# Altium schematic sheet BGMCtrlInterface.SchDoc(BGMCtrlInterface)
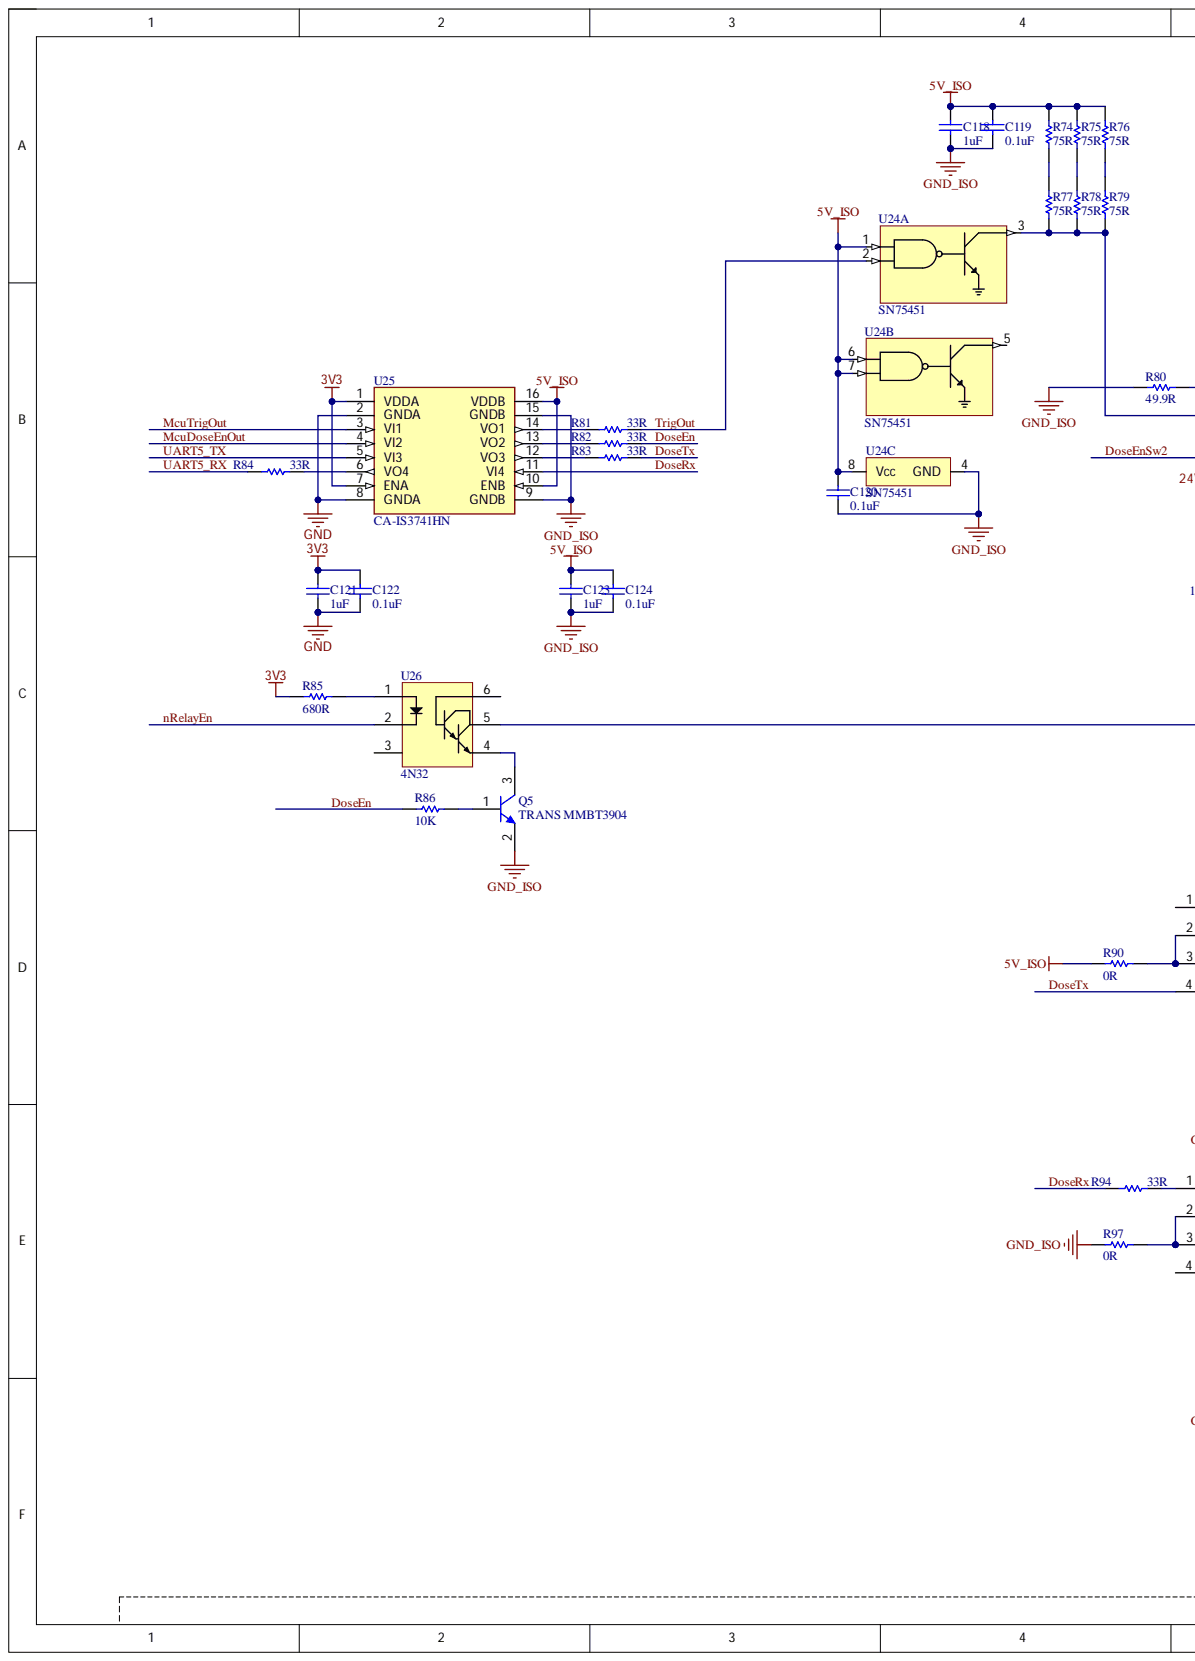
[diagram p0: BGMCtrlInterface.SchDoc, left]
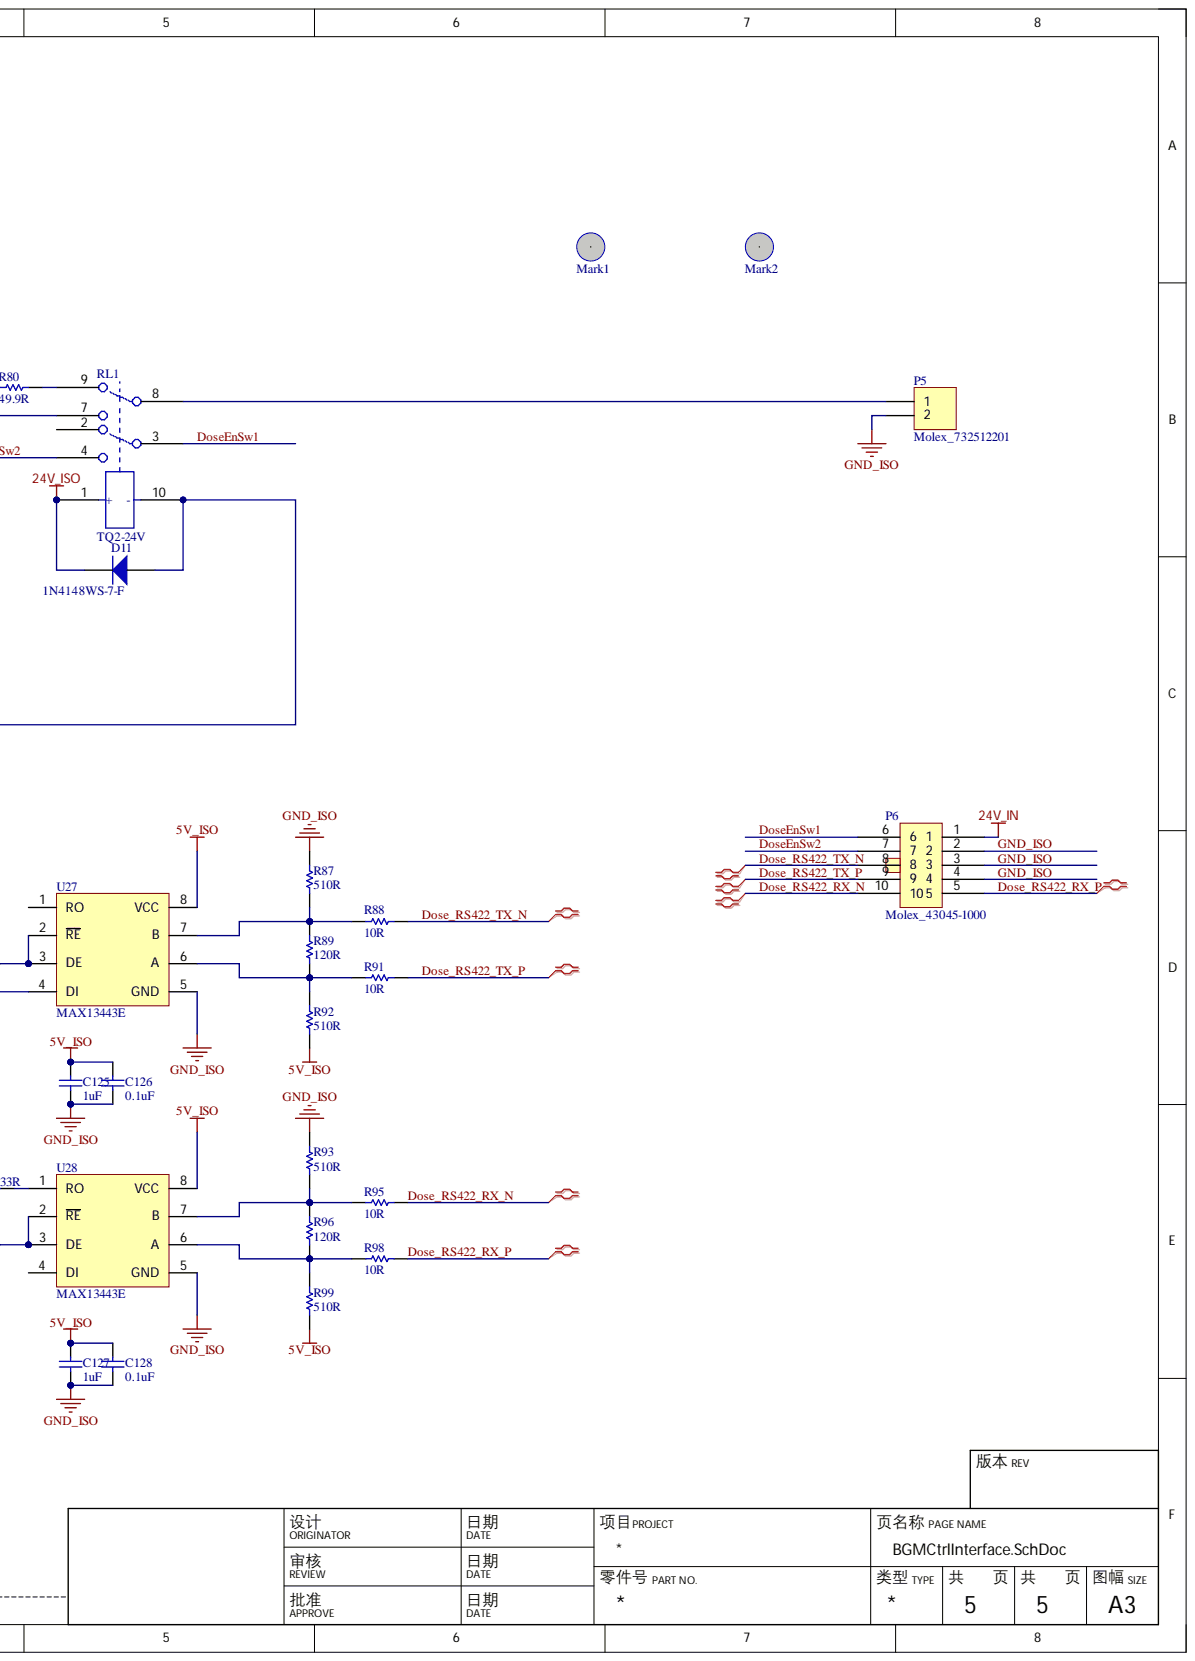
[diagram p1: BGMCtrlInterface.SchDoc, right]

## Parts
C118  1uF  [C0603(1608)]
C119  0.1uF  [C0402(1005)]
C120  0.1uF  [C0402(1005)]
C121  1uF  [C0603(1608)]
C122  0.1uF  [C0402(1005)]
C123  1uF  [C0603(1608)]
C124  0.1uF  [C0402(1005)]
C125  1uF  [C0603(1608)]
C126  0.1uF  [C0402(1005)]
C127  1uF  [C0603(1608)]
C128  0.1uF  [C0402(1005)]
D11  1N4148WS-7-F  [Diode-SOD323-2-A_V]
Mark1  Mark  [Mark]
Mark2  Mark  [Mark]
P5  Molex_732512201  [Molex_732512201]
P6  Molex_43045-1000  [Molex_43045-1000]
Q5  TRANS MMBT3904  [SOT-23-3]
R74  75R  [R0603(1608)]
R75  75R  [R0603(1608)]
R76  75R  [R0603(1608)]
R77  75R  [R0603(1608)]
R78  75R  [R0603(1608)]
R79  75R  [R0603(1608)]
R80  49.9R  [R0603(1608)]
R81  33R  [R0603(1608)]
R82  33R  [R0603(1608)]
R83  33R  [R0603(1608)]
R84  33R  [R0603(1608)]
R85  680R  [R0603(1608)]
R86  10K  [R0603(1608)]
R87  510R  [R0603(1608)]
R88  10R  [R0603(1608)]
R89  120R  [R0603(1608)]
R90  0R  [R0603(1608)]
R91  10R  [R0603(1608)]
R92  510R  [R0603(1608)]
R93  510R  [R0603(1608)]
R94  33R  [R0603(1608)]
R95  10R  [R0603(1608)]
R96  120R  [R0603(1608)]
R97  0R  [R0603(1608)]
R98  10R  [R0603(1608)]
R99  510R  [R0603(1608)]
RL1  TQ2-24V  [TQ2-5]
U24  SN75451  [SOIC8_N]  (parts A,B,C)
U25  CA-IS3741HN  [CA-IS374xxN]
U26  4N32  [SMD-6]
U27  MAX13443E  [SOIC8]
U28  MAX13443E  [SOIC8]

## Nets
3V3: C121.2 C122.2 R85.1 U25.1(VDDA) U25.7(ENA)
5V_ISO: C118.2 C119.2 C120.2 C123.2 C124.2 C125.2 C126.2 C127.2 C128.2 R74.2 R75.2 R76.2 R90.1 R92.1 R99.1 U24.1 U24.6 U24.7 U24.8(Vcc) U25.10(ENB) U25.16(VDDB) U27.8(VCC) U28.8(VCC)
24V_IN: P6.1
24V_ISO: D11.2 RL1.1(+)
GND: C121.1 C122.1 U25.2(GNDA) U25.8(GNDA)
Dose_RS422_RX_N: P6.10 R95.2
Dose_RS422_RX_P: P6.5 R98.2
Dose_RS422_TX_N: P6.8 R88.2
Dose_RS422_TX_P: P6.9 R91.2
DoseEn: R82.2 R86.2
DoseEnSw1: P6.6 RL1.3
DoseEnSw2: P6.7 RL1.4
DoseRx: R94.1 U25.11(VI4)
DoseTx: R83.2 U27.4(DI)
GND_ISO: C118.1 C119.1 C120.1 C123.1 C124.1 C125.1 C126.1 C127.1 C128.1 P5.2 P6.2 P6.3 P6.4 Q5.2 R80.1 R87.2 R93.2 R97.1 U24.4(GND) U25.9(GNDB) U25.15(GNDB) U27.5(GND) U28.5(GND)
McuDoseEnOut: U25.4(VI2)
McuTrigOut: U25.3(VI1)
nRelayEn: U26.2
TrigOut: R81.2 U24.2
UART5_RX: R84.1
UART5_TX: U25.5(VI3)
NetD11_1: D11.1 RL1.10(-) U26.5
NetP5_1: P5.1 RL1.8
NetQ5_1: Q5.1 R86.1
NetQ5_3: Q5.3 U26.4
NetR74_1: R74.1 R77.2
NetR75_1: R75.1 R78.2
NetR76_1: R76.1 R79.2
NetR77_1: R77.1 R78.1 R79.1 RL1.7 U24.3
NetR80_2: R80.2 RL1.9
NetR81_1: R81.1 U25.14(VO1)
NetR82_1: R82.1 U25.13(VO2)
NetR83_1: R83.1 U25.12(VO3)
NetR84_2: R84.2 U25.6(VO4)
NetR85_2: R85.2 U26.1
NetR87_1: R87.1 R88.1 R89.1 U27.7(B)
NetR89_2: R89.2 R91.1 R92.2 U27.6(A)
NetR90_2: R90.2 U27.2(RE) U27.3(DE)
NetR93_1: R93.1 R95.1 R96.1 U28.7(B)
NetR94_2: R94.2 U28.1(RO)
NetR96_2: R96.2 R98.1 R99.2 U28.6(A)
NetR97_2: R97.2 U28.2(RE) U28.3(DE)

Not connected: Mark1 1; Mark2 1; P6 0; RL1 2; U24 5; U26 3 6; U27 1(RO); U28 4(DI)

## Library data of the parts
C118 C121 C123 C125 C127 = Cap 1uF 0603-50V: ceramic 50V; 价格: ¥1.96@100; 制造商: TDK; 型号: CGA3E3X5R1H105K080AB; Component Kind: Standard; Library Name: RCL_release.SchLib; 供应商及库存编号: e络盟 2210922
C119 C120 C122 C124 C126 C128 = Cap 0.1uF 0402-10V: ceramic 10V; 价格: ¥0.1@100; 制造商: KEMET; 型号: C0402C104K8RACTU; Component Kind: Standard; Library Name: RCL_release.SchLib; 供应商及库存编号: e络盟 2429351
D11 = DIODE 1N4148WS-7-F: 75V 150MA SOD323; 价格: ￥0.38@100; 制造商: Diodes Incorporated; Component Kind: Standard; Library Name: Transistor_temp.SchLib; 供应商及库存编号: e络盟  1843678; 型号: 1N4148WS-7-F
P5 = Molex_732512201: sma jack Right_angle PCB 50ohm; Component Kind: Standard; Library Name: Connector_temp.SchLib
Q5 = MMBT3904: NPN 40V 0.2A SOT23; 价格: ￥0.5184@100; 制造商: Diodes; 型号: MMBT3904-7-F; Component Kind: Standard; Library Name: Transistor_release.SchLib; 供应商及库存编号: digikey MMBT3904-FDICT-ND
R74-R79 = Res 75R 0603: Res ±1%; Component Kind: Standard; Library Name: RCL_release.SchLib
R80 = Res 49.9R 0603: Res ±1%; Component Kind: Standard; Library Name: RCL_release.SchLib
R81-R84 R94 = Res 33R 0603: Res ±1%; 名称: 贴片电阻; Component Kind: Standard; Library Name: RCL_release.SchLib
R85 = Res 680R 0603: Res ±1%; Component Kind: Standard; Library Name: RCL_release.SchLib
R86 = Res 10K 0603: Res ±1%; 名称: 贴片电阻; Component Kind: Standard; Library Name: RCL_release.SchLib
R87 R92 R93 R99 = Res 510R 0603: Res ±1%; Component Kind: Standard; Library Name: RCL_release.SchLib
R88 R91 R95 R98 = Res 10R 0603: Res ±1%; Component Kind: Standard; Library Name: RCL_release.SchLib
R89 R96 = Res 120R 0603: Res ±1%; Component Kind: Standard; Library Name: RCL_release.SchLib
R90 R97 = Res 0R 0603: Res ±1%; Component Kind: Standard; Library Name: RCL_release.SchLib
RL1 = TQ2-24V: RELAY TELECOM DPDT 1A 24V; 价格: ￥24.97@100; 制造商: PANASONIC ELECTRIC WORKS; Component Kind: Standard; Library Name: IC.SchLib; 供应商及库存编号: e络盟 1175717; 型号: TQ2-24V
U24 = SN75451: D-TYPE POS TRG DUAL 14SSOP; 价格: $0.627@100; 制造商: Texas Instruments; 型号: SN75451BD; Component Kind: Standard; Library Name: IC_temp.SchLib; 供应商及库存编号: digikey 296-9912-5-ND
U26 = 4N32: OPTOISO 4.17KV DARL W/BASE 6SMD; 价格: $0.505@100; 制造商: ON Semiconductor; 型号: 4N32SR2M; Component Kind: Standard; Library Name: IC_release.SchLib; 供应商及库存编号: digikey 4N32SR2MCT-ND
U27 U28 = MAX13443E: ±15kV ESD-Protected, ±80V Fault-Protected, Fail-Safe RS-485/J1708 Transceivers; Component Kind: Standard; Library Name: IC_temp.SchLib
Mark1 Mark2 = Mark: Component Kind: Standard; Description: Mark; Library Name: Connector_temp.SchLib
P6 = Molex_43045-1000: Component Kind: Standard; Library Name: Connector_release.SchLib
U25 = CA-IS3741HN: Component Kind: Standard; Description: CA-IS3741HN; Library Name: IC_release.SchLib
